# Altium schematic sheet main.SchDoc(main)
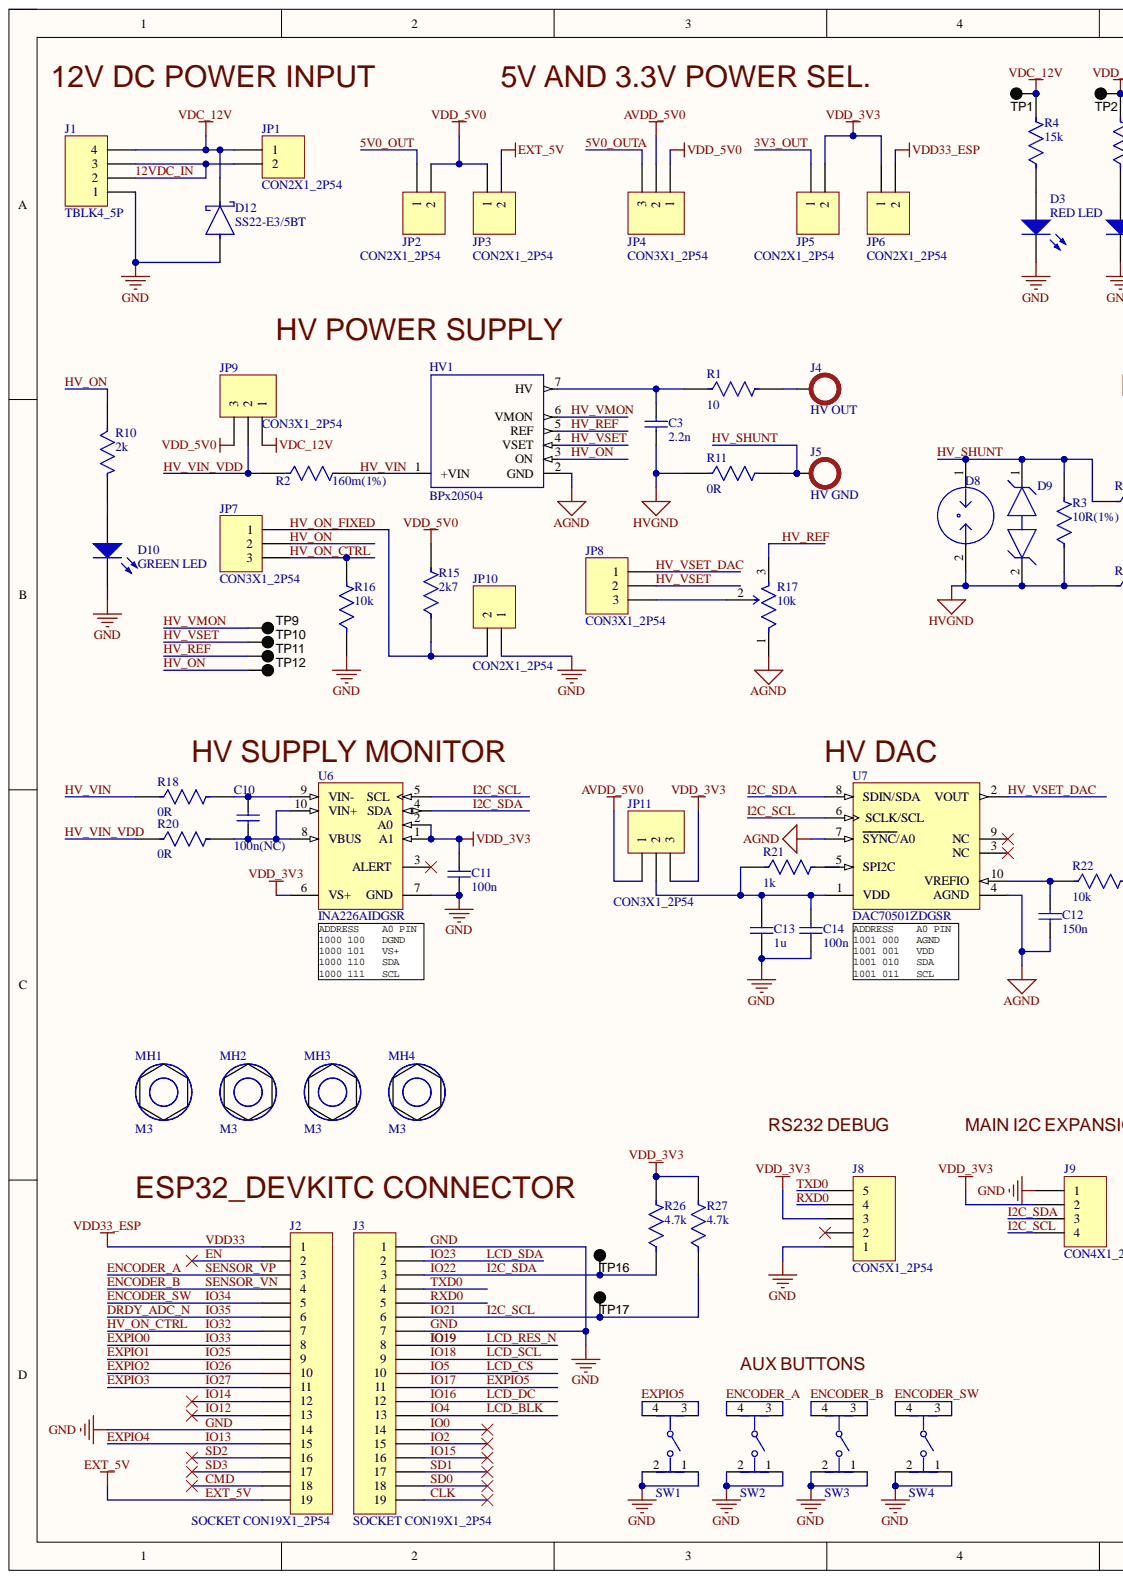
[diagram p0: main.SchDoc, left]
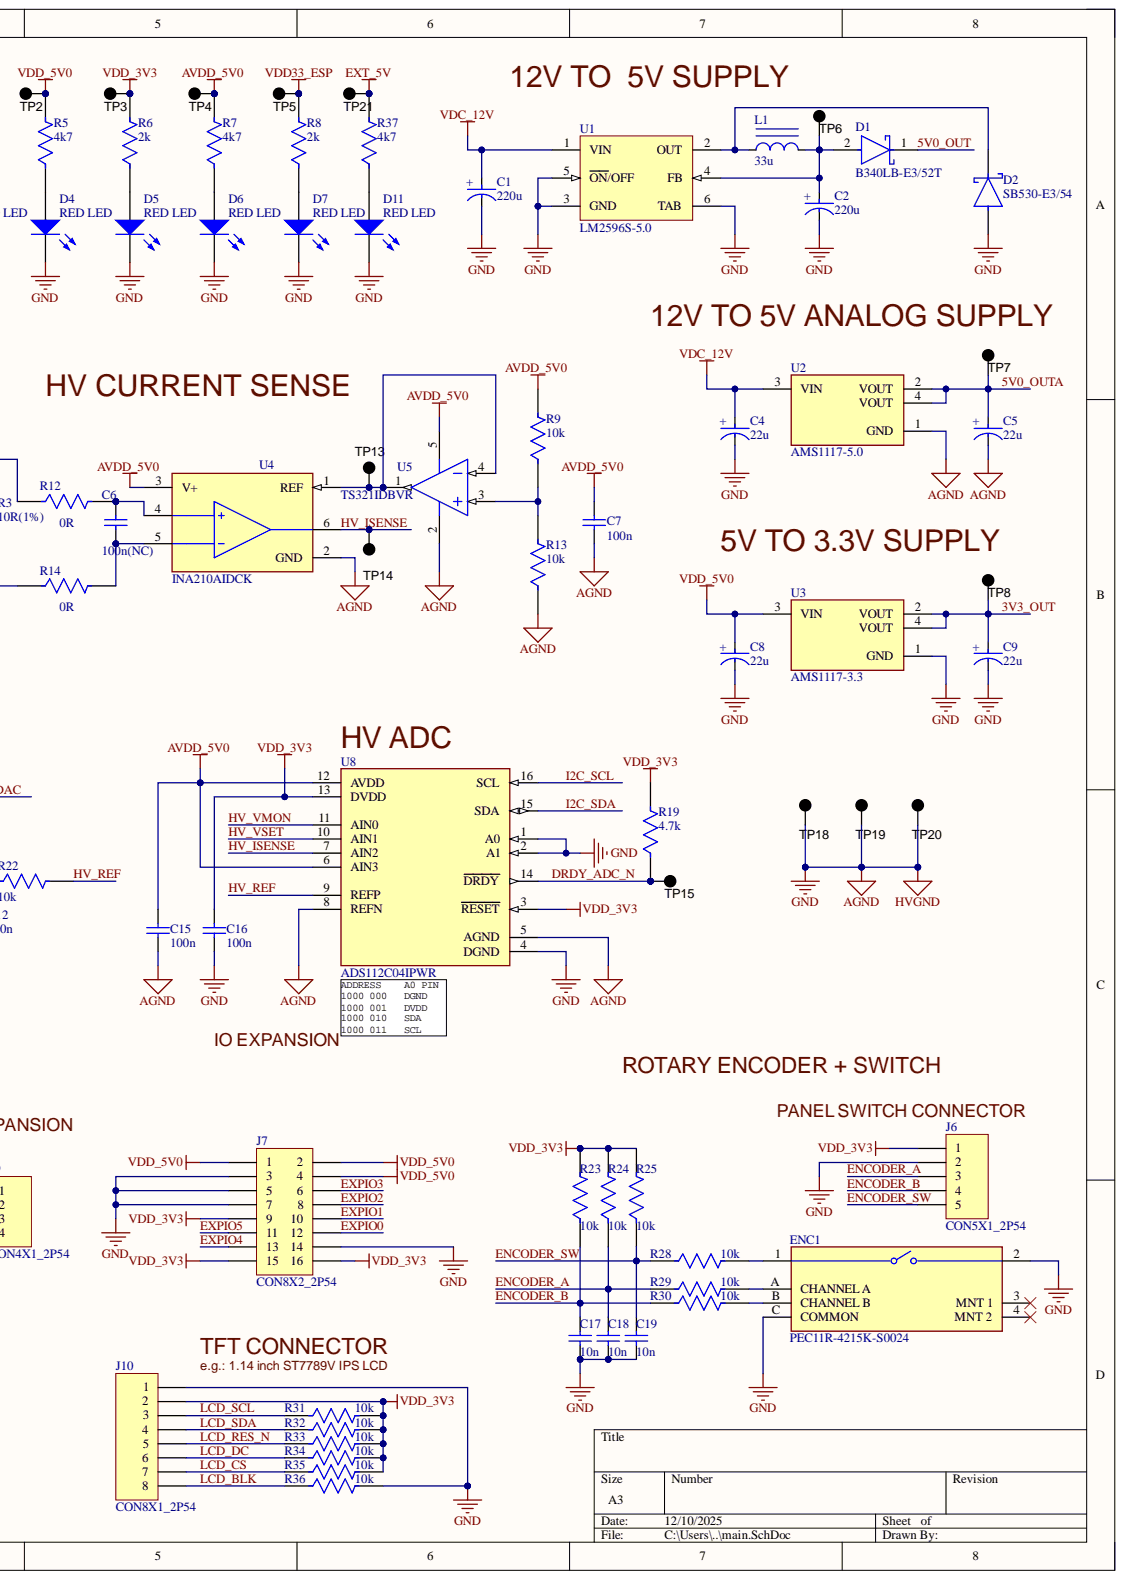
[diagram p1: main.SchDoc, right]

## Parts
C1  220u  [KEMET AlPo Cap 8Dx11.5H 3.5mm]
C2  220u  [Panasonic Electrolytic Cap 10Dx12H 3.5mm]
C3  2.2n  [564R60GAD22_VIS]
C4  22u  [FP-TAJA-MFG]
C5  22u  [FP-TAJA-MFG]
C6  100n(NC)  [FP-C0805C-DN-IPC_A]
C7  100n  [FP-C0805C-DN-IPC_A]
C8  22u  [FP-TAJA-MFG]
C9  22u  [FP-TAJA-MFG]
C10  100n(NC)  [FP-C0805C-DN-IPC_A]
C11  100n  [FP-C0805C-DN-IPC_A]
C12  150n  [FP-C0805C-DN-IPC_A]
C13  1u  [FP-C0805C-DN-IPC_A]
C14  100n  [FP-C0805C-DN-IPC_A]
C15  100n  [FP-C0805C-DN-IPC_A]
C16  100n  [FP-C0805C-DN-IPC_A]
C17  10n  [FP-C0805C-DN-IPC_A]
C18  10n  [FP-C0805C-DN-IPC_A]
C19  10n  [FP-C0805C-DN-IPC_A]
D1  B340LB-E3/52T  [FP-95401-MFG]
D2  SB530-E3/54  [Diode DO-201AD, Axial]
D3  RED LED  [0805 SMD LED]
D4  RED LED  [0805 SMD LED]
D5  RED LED  [0805 SMD LED]
D6  RED LED  [0805 SMD LED]
D7  RED LED  [0805 SMD LED]
D8  2053-07-SM-RPLF  [FP-2053-XX-SM-RPLF-MFG]  (value not drawn)
D9  CDSOD323-T12SC  [FP-SOD-323-MFG]  (value not drawn)
D10  GREEN LED  [0805 SMD LED]
D11  RED LED  [0805 SMD LED]
D12  SS22-E3/5BT  [FP-95401-MFG]
ENC1  PEC11R-4215K-S0024  [FP-PEC11R-1/4xxxx-S00xx-MFG]
HV1  BPx20504  [BPS1/3W]
J1  TBLK4_5P  [4-pin Terminal Block Wurth Elektronik 691137710004]
J2  SOCKET CON19X1_2P54  [CON19X1_2P54]
J3  SOCKET CON19X1_2P54  [CON19X1_2P54]
J4  HV OUT  [Hole Pad c195h130]
J5  HV GND  [Hole Pad c195h130]
J6  CON5X1_2P54  [CON5X1_2P54]
J7  CON8X2_2P54  [CON8X2_2P54]
J8  CON5X1_2P54  [CON5X1_2P54]
J9  CON4X1_2P54  [CON4X1_2P54]
J10  CON8X1_2P54  [CON8X1_2P54]
JP1  CON2X1_2P54  [CON2X1_2P54]
JP2  CON2X1_2P54  [CON2X1_2P54]
JP3  CON2X1_2P54  [CON2X1_2P54]
JP4  CON3X1_2P54  [CON3X1_2P54]
JP5  CON2X1_2P54  [CON2X1_2P54]
JP6  CON2X1_2P54  [CON2X1_2P54]
JP7  CON3X1_2P54  [CON3X1_2P54]
JP8  CON3X1_2P54  [CON3X1_2P54]
JP9  CON3X1_2P54  [CON3X1_2P54]
JP10  CON2X1_2P54  [CON2X1_2P54]
JP11  CON3X1_2P54  [CON3X1_2P54]
L1  33u  [Inductor SMD 12.5x12.5mm 8mmH]
MH1  M3  [M3 Mounting Hole]
MH2  M3  [M3 Mounting Hole]
MH3  M3  [M3 Mounting Hole]
MH4  M3  [M3 Mounting Hole]
R1  10  [Axial Resistor Thru-Hole MFR50 12.5mm pitch]
R2  160m(1%)  [1206]
R3  10R(1%)  [1206]
R4  15k  [FP-MCWR08-IPC_A]
R5  4k7  [FP-MCWR08-IPC_A]
R6  2k  [FP-MCWR08-IPC_A]
R7  4k7  [FP-MCWR08-IPC_A]
R8  2k  [FP-MCWR08-IPC_A]
R9  10k  [FP-MCWR08-IPC_A]
R10  2k  [FP-MCWR08-IPC_A]
R11  0R  [FP-MCWR08-IPC_A]
R12  0R  [FP-MCWR08-IPC_A]
R13  10k  [FP-MCWR08-IPC_A]
R14  0R  [FP-MCWR08-IPC_A]
R15  2k7  [FP-MCWR08-IPC_A]
R16  10k  [FP-MCWR08-IPC_A]
R17  10k  [TrimPot-3296Y]
R18  0R  [FP-MCWR08-IPC_A]
R19  4.7k  [FP-MCWR08-IPC_A]
R20  0R  [FP-MCWR08-IPC_A]
R21  1k  [FP-MCWR08-IPC_A]
R22  10k  [FP-MCWR08-IPC_A]
R23  10k  [FP-MCWR08-IPC_A]
R24  10k  [FP-MCWR08-IPC_A]
R25  10k  [FP-MCWR08-IPC_A]
R26  4.7k  [FP-MCWR08-IPC_A]
R27  4.7k  [FP-MCWR08-IPC_A]
R28  10k  [FP-MCWR08-IPC_A]
R29  10k  [FP-MCWR08-IPC_A]
R30  10k  [FP-MCWR08-IPC_A]
R31  10k  [FP-MCWR08-IPC_A]
R32  10k  [FP-MCWR08-IPC_A]
R33  10k  [FP-MCWR08-IPC_A]
R34  10k  [FP-MCWR08-IPC_A]
R35  10k  [FP-MCWR08-IPC_A]
R36  10k  [FP-MCWR08-IPC_A]
R37  4k7  [FP-MCWR08-IPC_A]
SW1  PTS810SJM250SMTRLFS  [FP-PTS810SJM250SMTRLFS-MFG]  (value not drawn)
SW2  PTS810SJM250SMTRLFS  [FP-PTS810SJM250SMTRLFS-MFG]  (value not drawn)
SW3  PTS810SJM250SMTRLFS  [FP-PTS810SJM250SMTRLFS-MFG]  (value not drawn)
SW4  PTS810SJM250SMTRLFS  [FP-PTS810SJM250SMTRLFS-MFG]  (value not drawn)
TP1  5011  [Keystone5011]  (value not drawn)
TP2  5011  [Keystone5011]  (value not drawn)
TP3  5011  [Keystone5011]  (value not drawn)
TP4  5011  [Keystone5011]  (value not drawn)
TP5  5011  [Keystone5011]  (value not drawn)
TP6  5011  [Keystone5011]  (value not drawn)
TP7  5011  [Keystone5011]  (value not drawn)
TP8  5011  [Keystone5011]  (value not drawn)
TP9  5011  [Keystone5011]  (value not drawn)
TP10  5011  [Keystone5011]  (value not drawn)
TP11  5011  [Keystone5011]  (value not drawn)
TP12  5011  [Keystone5011]  (value not drawn)
TP13  5011  [Keystone5011]  (value not drawn)
TP14  5011  [Keystone5011]  (value not drawn)
TP15  5011  [Keystone5011]  (value not drawn)
TP16  5011  [Keystone5011]  (value not drawn)
TP17  5011  [Keystone5011]  (value not drawn)
TP18  5011  [Keystone5011]  (value not drawn)
TP19  5011  [Keystone5011]  (value not drawn)
TP20  5011  [Keystone5011]  (value not drawn)
TP21  5011  [Keystone5011]  (value not drawn)
U1  LM2596S-5.0  [KTT0005B_M]
U2  AMS1117-5.0  [FP-AMS1117-IPC_A]
U3  AMS1117-3.3  [FP-AMS1117-IPC_A]
U4  INA210AIDCK  [FP-DCK0006A-IPC_A]
U5  TS321IDBVR  [DBV0005A_N]
U6  INA226AIDGSR  [DGS0010A_M]
U7  DAC70501ZDGSR  [TI-DGS10_M]
U8  ADS112C04IPWR  [FP-PW0016A-IPC_A]

## Nets
AVDD_5V0: C7.2 C15.2 JP4.2 JP11.1 R7.2 R9.2 TP4.1 U4.3(V+) U5.5 U8.6(AIN3) U8.12(AVDD)
VDC_12V: C1.2 C4.2 D12.1 J1.4 JP1.1 JP9.1 R4.2 TP1.1 U1.1(VIN) U2.3(VIN)
VDD_3V3: C11.2 C16.2 J6.1 J7.9 J7.15 J7.16 J8.3 J9.2 J10.2 JP5.2 JP6.1 JP11.3 R6.2 R19.2 R23.2 R24.2 R25.2 R26.2 R27.2 R31.2 R32.2 R33.2 R34.2 R35.2 TP3.1 U6.1(A1) U6.2(A0) U6.6(VS+) U8.3(RESET) U8.13(DVDD)
VDD_5V0: C8.2 J7.1 J7.2 J7.4 JP2.2 JP3.1 JP4.1 JP9.3 R5.2 R15.2 TP2.1 U3.3(VIN)
3V3_OUT: C9.2 JP5.1 TP8.1 U3.2(VOUT) U3.4(VOUT)
5V0_OUT: D1.1 JP2.1
5V0_OUTA: C5.2 JP4.3 TP7.1 U2.2(VOUT) U2.4(VOUT)
12VDC_IN: J1.2 J1.3 JP1.2
CLK: J3.19
CMD: J2.18
DRDY_ADC_N: J2.6 R19.1 TP15.1 U8.14(DRDY)
EN: J2.2
ENCODER_A: C18.2 J2.3 J6.3 R24.1 R29.1 SW2.3 SW2.4
ENCODER_B: C17.2 J2.4 J6.4 R23.1 R30.1 SW3.3 SW3.4
ENCODER_SW: C19.2 J2.5 J6.5 R25.1 R28.1 SW4.3 SW4.4
EXPIO0: J2.8 J7.12
EXPIO1: J2.9 J7.10
EXPIO2: J2.10 J7.8
EXPIO3: J2.11 J7.6
EXPIO4: J2.15 J7.13
EXPIO5: J3.11 J7.11 SW1.3 SW1.4
EXT_5V: J2.19 JP3.2 R37.2 TP21.1
GND: C1.1 C2.1 C3.1 C4.1 C5.1 C7.1 C8.1 C9.1 C11.1 C12.1 C13.1 C14.1 C15.1 C16.1 C17.1 C18.1 C19.1 D2.2 D3.2 D4.2 D5.2 D6.2 D7.2 D8.2 D9.2 D10.2 D11.2 D12.2 ENC1.2 ENC1.C(COMMON) HV1.2(GND) J1.1 J2.14 J3.1 J3.7 J6.2 J7.3 J7.5 J7.7 J7.14 J8.1 J9.1 J10.1 JP10.1 R3.1 R11.1 R13.1 R14.1 R16.1 R17.1 R36.2 SW1.1 SW1.2 SW2.1 SW2.2 SW3.1 SW3.2 SW4.1 SW4.2 TP18.1 TP19.1 TP20.1 U1.3(GND) U1.5(ON/OFF) U1.6(TAB) U2.1(GND) U3.1(GND) U4.2(GND) U5.2 U6.7(GND) U7.4(AGND) U7.7(SYNC/A0) U8.1(A0) U8.2(A1) U8.4(DGND) U8.5(AGND) U8.8(REFN)
HV_ISENSE: TP14.1 U4.6 U8.7(AIN2)
HV_ON: HV1.3(ON) JP7.2 R10.1 TP12.1
HV_ON_CTRL: J2.7 JP7.3 R16.2
HV_ON_FIXED: JP7.1 JP10.2 R15.1
HV_REF: HV1.5(REF) R17.3 R22.2 TP11.1 U8.9(REFP)
HV_SHUNT: D8.1 D9.1 J5.1 R3.2 R11.2 R12.1
HV_VIN: HV1.1(+VIN) R2.2 R18.1
HV_VIN_VDD: JP9.2 R2.1 R20.1
HV_VMON: HV1.6(VMON) TP9.1 U8.11(AIN0)
HV_VSET: HV1.4(VSET) JP8.2 TP10.1 U8.10(AIN1)
HV_VSET_DAC: JP8.1 U7.2(VOUT)
I2C_SCL: J3.6 J9.4 R27.1 TP17.1 U6.5(SCL) U7.6(SCLK/SCL) U8.16(SCL)
I2C_SDA: J3.3 J9.3 R26.1 TP16.1 U6.4(SDA) U7.8(SDIN/SDA) U8.15(SDA)
IO0: J3.14
IO2: J3.15
IO4: J3.13 J10.8 R36.1
IO5: J3.10 J10.7 R35.1
IO12: J2.13
IO14: J2.12
IO15: J3.16
IO16: J3.12 J10.6 R34.1
IO18: J3.9 J10.3 R31.1
IO19: J3.8 J10.5 R33.1
IO23: J3.2 J10.4 R32.1
RXD0: J3.5 J8.4
SD0: J3.18
SD1: J3.17
SD2: J2.16
SD3: J2.17
TXD0: J3.4 J8.5
VDD33: J2.1 JP6.2 R8.2 TP5.1
NetC2_2: C2.2 D1.2 L1.2 TP6.1 U1.4(FB)
NetC3_2: C3.2 HV1.7(HV) R1.1
NetC6_1: C6.1 R14.2 U4.5
NetC6_2: C6.2 R12.2 U4.4
NetC10_1: C10.1 R20.2 U6.8(VBUS) U6.10(VIN+)
NetC10_2: C10.2 R18.2 U6.9(VIN-)
NetC12_2: C12.2 R22.1 U7.10(VREFIO)
NetC13_2: C13.2 C14.2 JP11.2 R21.1 U7.1(VDD)
NetD2_1: D2.1 L1.1 U1.2(OUT)
NetD3_1: D3.1 R4.1
NetD4_1: D4.1 R5.1
NetD5_1: D5.1 R6.1
NetD6_1: D6.1 R7.1
NetD7_1: D7.1 R8.1
NetD10_1: D10.1 R10.2
NetD11_1: D11.1 R37.1
NetENC1_1: ENC1.1 R28.2
NetENC1_A: ENC1.A(CHANNEL_A) R29.2
NetENC1_B: ENC1.B(CHANNEL_B) R30.2
NetJ4_1: J4.1 R1.2
NetJP8_3: JP8.3 R17.2
NetR9_1: R9.1 R13.2 U5.3
NetR21_2: R21.2 U7.5(SPI2C)
NetTP13_1: TP13.1 U4.1(REF) U5.1 U5.4

Not connected: ENC1 3(MNT_1) 4(MNT_2); J8 2; U6 3(ALERT); U7 3(NC) 9(NC)
